# Altium schematic sheet Konektori_SCH.SchDoc
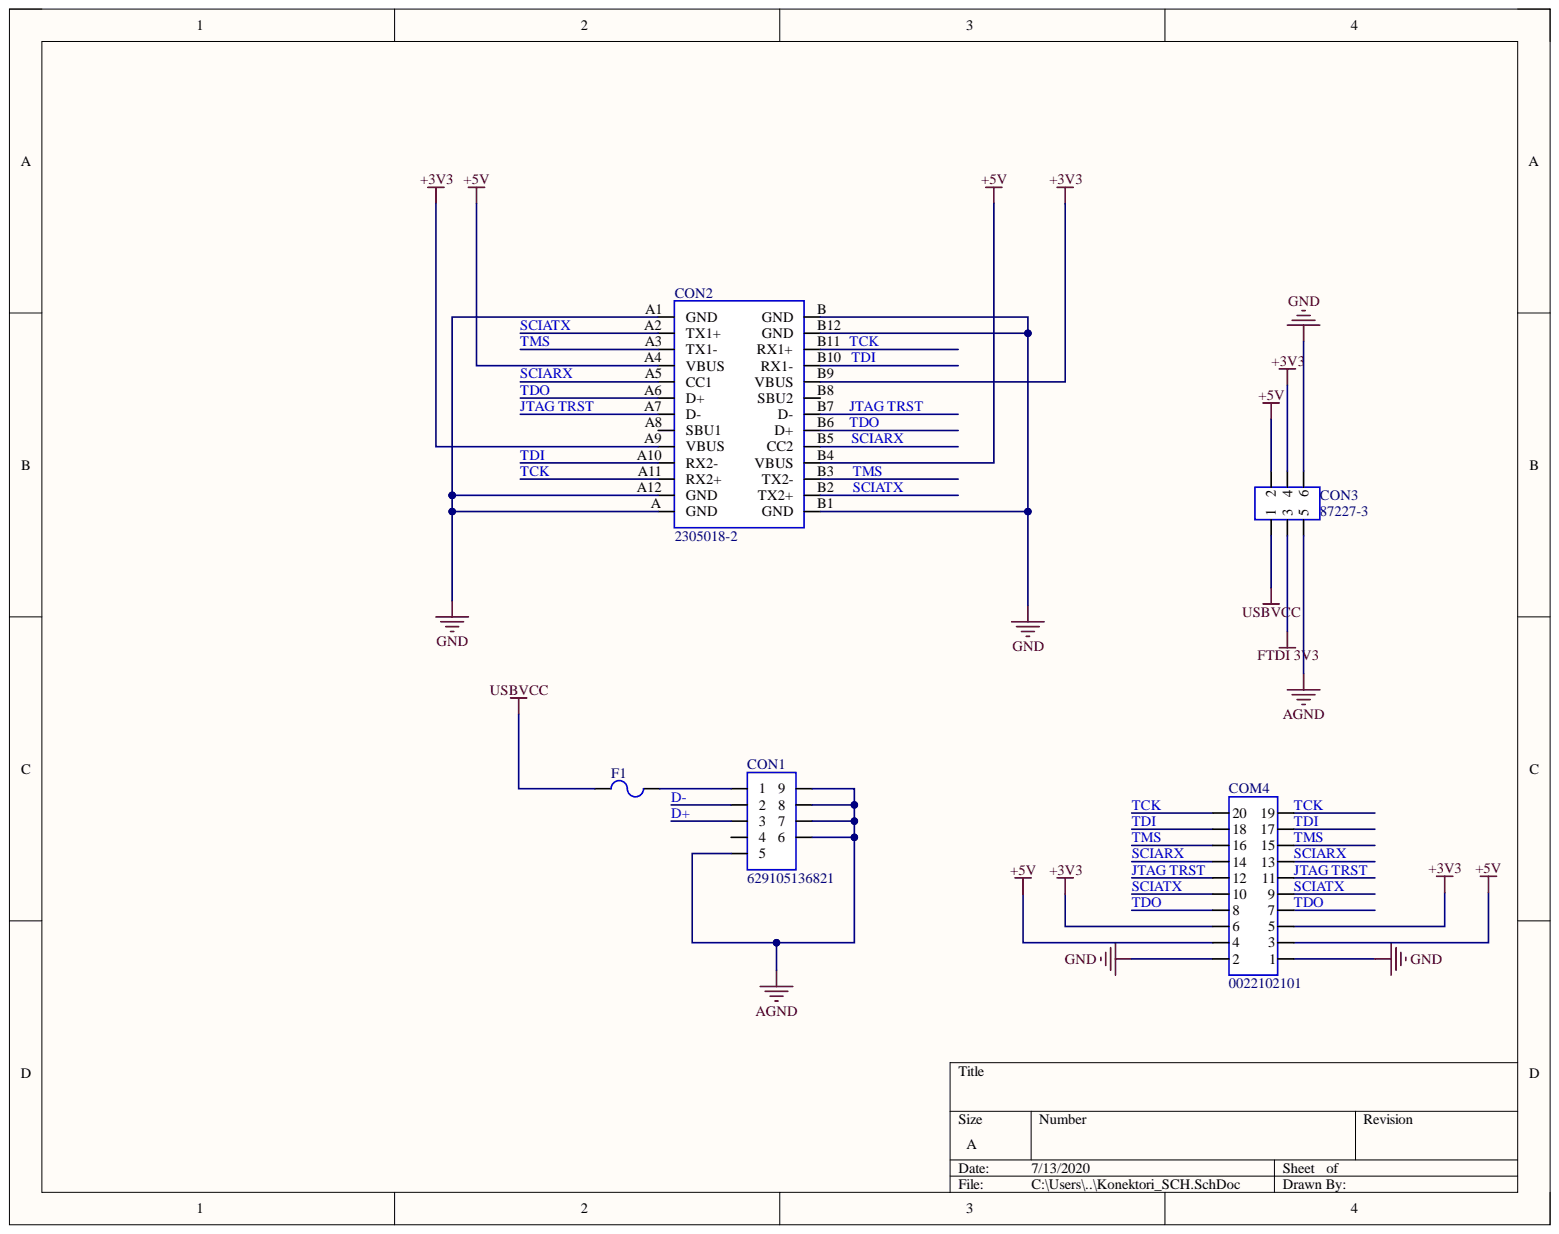
[diagram p0: Konektori_SCH.SchDoc]

## Parts
COM4  0022102101  [0022102101]
CON1  629105136821  [629105136821]
CON2  2305018-2  [2305018-2]
CON3  87227-3  [87227-3]
F1  MF-MSMF050-2  [MF-MSMF050-2]  (value not drawn)

## Nets
+3V3: COM4.5 COM4.6 CON2.A9(VBUS) CON2.B9(VBUS) CON3.4
+5V: COM4.3 COM4.4 CON2.A4(VBUS) CON2.B4(VBUS) CON3.2
AGND: CON1.5 CON1.6 CON1.7 CON1.8 CON1.9 CON3.5
FTDI 3V3: CON3.3
GND: COM4.1 COM4.2 CON2.A(GND) CON2.A1(GND) CON2.A12(GND) CON2.B(GND) CON2.B1(GND) CON2.B12(GND) CON3.6
USBVCC: CON3.1 F1.1
D+: CON1.3
D-: CON1.2
JTAG TRST: COM4.11 COM4.12 CON2.A7(D-) CON2.B7(D-)
SCIARX: COM4.13 COM4.14 CON2.A5(CC1) CON2.B5(CC2)
SCIATX: COM4.9 COM4.10 CON2.A2(TX1+) CON2.B2(TX2+)
TCK: COM4.19 COM4.20 CON2.A11(RX2+) CON2.B11(RX1+)
TDI: COM4.17 COM4.18 CON2.A10(RX2-) CON2.B10(RX1-)
TDO: COM4.7 COM4.8 CON2.A6(D+) CON2.B6(D+)
TMS: COM4.15 COM4.16 CON2.A3(TX1-) CON2.B3(TX2-)
NetCON1_1: CON1.1 F1.2

Not connected: CON1 4; CON2 A8(SBU1) B8(SBU2)

## Library data of the parts
COM4 = 0022102101: Hederi 10 pozicija; Component Kind: Standard; Supplier 1: Digi-Key; Supplier Part Number 1: WM2730-ND; Library Name: J_Tag.SchLib
CON1 = 629105136821: USB B mikro konektor; Component Kind: Standard; Supplier 1: Digi-Key; Supplier Part Number 1: 732-3155-6-ND; Library Name: J_Tag.SchLib
CON2 = 2305018-2: USB C konektor; Component Kind: Standard; Supplier 1: Digi-Key; Supplier Part Number 1: A132569DKR-ND; Library Name: J_Tag.SchLib
CON3 = 87227-3: Heder, 3x2, M; Component Kind: Standard; Supplier 1: Digi-Key; Supplier Part Number 1: A26569-ND; Library Name: J_Tag.SchLib
F1 = MF-MSMF050-2: F1; Component Kind: Standard; Supplier 1: Digi-Key; Supplier Part Number 1: MF-MSMF050-2DKR-ND; Library Name: J_Tag.SchLib
